# Altium schematic sheet TTFT_3.0.SchDoc(TTFT_3.0)
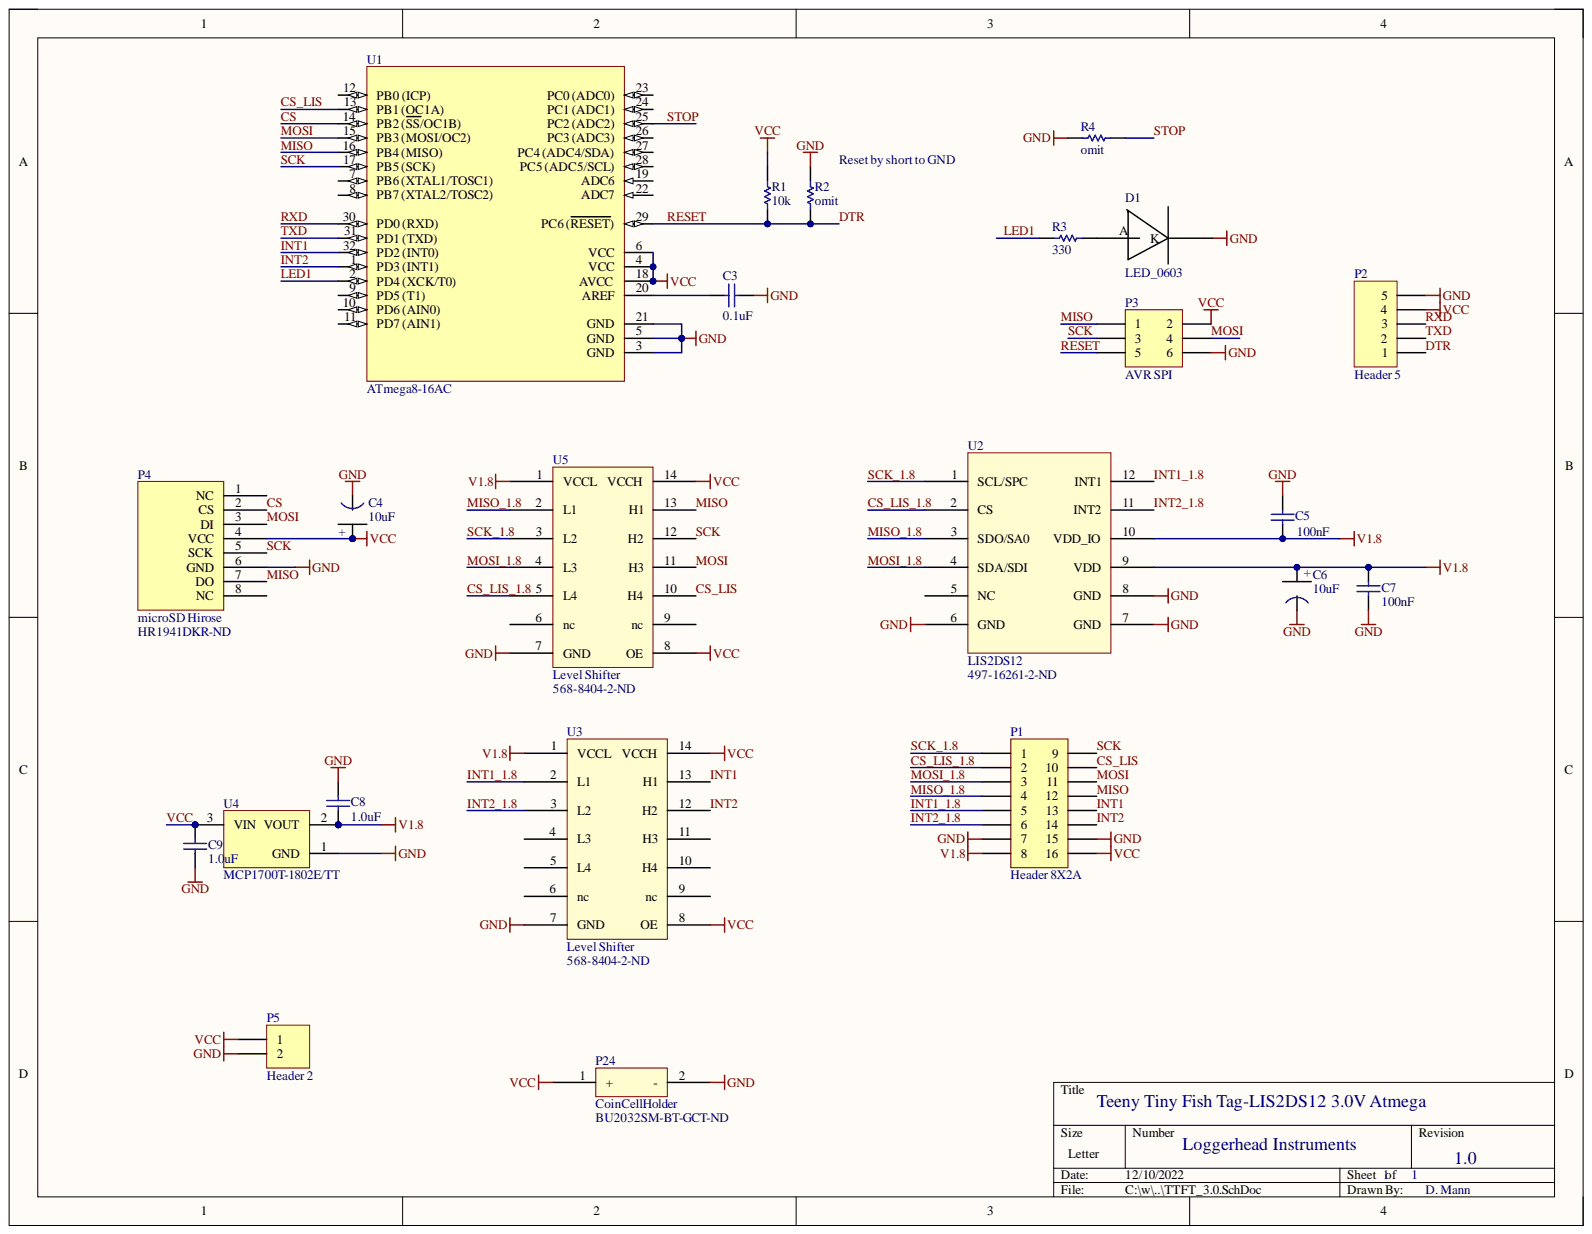
[diagram p0: TTFT_3.0.SchDoc]

## Parts
C3  0.1uF  [0402]
C4  10uF  [Tant0805]
C5  100nF  [0402]
C6  10uF  [Tant0805]
C7  100nF  [0402]
C8  1.0uF  [0402]
C9  1.0uF  [0402]
D1  LED_0603  [Diode0603]
P1  Header 8X2A  [HDR2X8_CEN]
P2  Header 5  [HDR1X5]
P3  AVR SPI  [HDR2X3]
P4  microSD Hirose  Supplier Part Number 1: HR1941DKR-ND  [DM3D microSD]
P5  Header 2  [HDR1X2]
P24  CoinCellHolder  Supplier Part Number 1: BU2032SM-BT-GCT-ND  [CoinCellHolder]
R1  10k  [3-0603]
R2  omit  [3-0603]
R3  330  [3-0603]
R4  omit  [3-0603]
U1  ATmega8-16AC  [32M1-A_M]
U2  LIS2DS12  Supplier Part Number 1: 497-16261-2-ND  [LGA-12]
U3  Level Shifter  Supplier Part Number 1: 568-8404-2-ND  [DHVQFN14]
U4  MCP1700T-1802E/TT  [SOT-23-TT3_N]
U5  Level Shifter  Supplier Part Number 1: 568-8404-2-ND  [DHVQFN14]

## Nets
GND: C3.2 C4.1 C5.2 C6.1 C7.2 C8.2 C9.2 D1.K P1.7 P1.15 P2.5 P3.6 P4.6(GND) P5.2 P24.2 R2.2 R4.2 U1.3(GND) U1.5(GND) U1.21(GND) U2.6(GND) U2.7(GND) U2.8(GND) U3.7(GND) U4.1 U5.7(GND)
V1.8: C5.1 C6.2 C7.1 C8.1 P1.8 U2.9(VDD) U2.10(VDD_IO) U3.1(VCCL) U4.2 U5.1(VCCL)
CS: P4.2(CS) U1.14(PB2_(SS/OC1B))
CS_LIS: P1.10 U1.13(PB1_(OC1A)) U5.10(H4)
CS_LIS_1.8: P1.2 U2.2(CS) U5.5(L4)
DTR: P2.1 P3.5 R1.1 R2.1 U1.29(PC6_(RESET))
INT1: P1.13 U1.32(PD2_(INT0)) U3.13(H1)
INT1_1.8: P1.5 U2.12(INT1) U3.2(L1)
INT2: P1.14 U1.1(PD3_(INT1)) U3.12(H2)
INT2_1.8: P1.6 U2.11(INT2) U3.3(L2)
LED1: R3.1 U1.2(PD4_(XCK/T0))
MISO: P1.12 P3.1 P4.7(DO) U1.16(PB4_(MISO)) U5.13(H1)
MISO_1.8: P1.4 U2.3(SDO/SA0) U5.2(L1)
MOSI: P1.11 P3.4 P4.3(DI) U1.15(PB3_(MOSI/OC2)) U5.11(H3)
MOSI_1.8: P1.3 U2.4(SDA/SDI) U5.4(L3)
RXD: P2.3 U1.30(PD0_(RXD))
SCK: P1.9 P3.3 P4.5(SCK) U1.17(PB5_(SCK)) U5.12(H2)
SCK_1.8: P1.1 U2.1(SCL/SPC) U5.3(L2)
STOP: R4.1 U1.25(PC2_(ADC2))
TXD: P2.2 U1.31(PD1_(TXD))
VCC: C4.2 C9.1 P1.16 P2.4 P3.2 P4.4(VCC) P5.1 P24.1 R1.2 U1.4(VCC) U1.6(VCC) U1.18(AVCC) U3.8(OE) U3.14(VCCH) U4.3 U5.8(OE) U5.14(VCCH)
NetC3_1: C3.1 U1.20(AREF)
NetD1_A: D1.A R3.2

Not connected: P4 1(NC) 8(NC); U1 7(PB6_(XTAL1/TOSC1)) 8(PB7_(XTAL2/TOSC2)) 9(PD5_(T1)) 10(PD6_(AIN0)) 11(PD7_(AIN1)) 12(PB0_(ICP)) 19(ADC6) 22(ADC7) 23(PC0_(ADC0)) 24(PC1_(ADC1)) 26(PC3_(ADC3)) 27(PC4_(ADC4/SDA)) 28(PC5_(ADC5/SCL)); U2 5(NC); U3 4(L3) 5(L4) 6(nc) 9(nc) 10(H4) 11(H3); U5 6(nc) 9(nc)

## Library data of the parts
C3 = C0402: Ceramic Chip Capacitor - Standard; Note: Footprint corresponds to dimensions for bulk cassette packaging. This case size has tightened tolerances.; Code_IPC: 1005 [0402]; Code_JEITA: 0402; Component Kind: Standard; ComponentLink1Description: Manufacturer Link; ComponentLink1URL: http://www.kemet.com/; ComponentLink2Description: Datasheet; ComponentLink2URL: http://www.kemet.com/kemet/web/homepage/kechome.nsf/vapubfilesname/F3102TA.pdf/$file/F3102TA.pdf; DatasheetDocument: Mar-2001; LatestRevisionDate: 19-Mar-2004; LatestRevisionNote: Silkscreen size reduced.; Library Name: KEMET Chip Capacitor.IntLib; PackageDocument: Mar-2001; PackageReference: 0402; Published: 17-Jul-2002; Signal Integrity: C0402; Simulation: CAP; Value: 0.1uF; Publisher: Altium Limited
C4 C6 = Tant0805: Component Kind: Standard; Value: 10uF; Library Name: Loggerhead.IntLib
C5 C7 = C0402: Ceramic Chip Capacitor - Standard; Note: Footprint corresponds to dimensions for bulk cassette packaging. This case size has tightened tolerances.; Value: 100pF; Code_IPC: 1005 [0402]; Code_JEITA: 0402; Component Kind: Standard; ComponentLink1Description: Manufacturer Link; ComponentLink1URL: http://www.kemet.com/; ComponentLink2Description: Datasheet; ComponentLink2URL: http://www.kemet.com/kemet/web/homepage/kechome.nsf/vapubfilesname/F3102TA.pdf/$file/F3102TA.pdf; DatasheetDocument: Mar-2001; LatestRevisionDate: 19-Mar-2004; LatestRevisionNote: Silkscreen size reduced.; Library Name: KEMET Chip Capacitor.IntLib; PackageDocument: Mar-2001; PackageReference: 0402; Published: 17-Jul-2002; Signal Integrity: C0402; Simulation: CAP; Publisher: Altium Limited
C8 C9 = C0402: Ceramic Chip Capacitor - Standard; Note: Footprint corresponds to dimensions for bulk cassette packaging. This case size has tightened tolerances.; Value: 0.1uF; Code_IPC: 1005 [0402]; Code_JEITA: 0402; Component Kind: Standard; ComponentLink1Description: Manufacturer Link; ComponentLink1URL: http://www.kemet.com/; ComponentLink2Description: Datasheet; ComponentLink2URL: http://www.kemet.com/kemet/web/homepage/kechome.nsf/vapubfilesname/F3102TA.pdf/$file/F3102TA.pdf; DatasheetDocument: Mar-2001; LatestRevisionDate: 19-Mar-2004; LatestRevisionNote: Silkscreen size reduced.; Library Name: KEMET Chip Capacitor.IntLib; PackageDocument: Mar-2001; PackageReference: 0402; Published: 17-Jul-2002; Signal Integrity: C0402; Simulation: CAP; Publisher: Altium Limited
P1 = Header 8X2A: Header, 8-Pin, Dual row; Component Kind: Standard; LatestRevisionDate: 17-Jul-2002; LatestRevisionNote: Re-released for DXP Platform.; Library Name: Miscellaneous Connectors.IntLib; Signal Integrity: Connector; Publisher: Altium Limited
P2 = Header 5: Header, 5-Pin; Component Kind: Standard; LatestRevisionDate: 17-Jul-2002; LatestRevisionNote: Re-released for DXP Platform.; Library Name: Miscellaneous Connectors.IntLib; Signal Integrity: Connector; Publisher: Altium Limited
P3 = Header 3X2: Header, 3-Pin, Dual row; Component Kind: Standard; LatestRevisionDate: 17-Jul-2002; LatestRevisionNote: Re-released for DXP Platform.; Library Name: Miscellaneous Connectors.IntLib; Signal Integrity: Connector; Publisher: Altium Limited
P5 = Header 2: Header, 2-Pin; Component Kind: Standard; LatestRevisionDate: 17-Jul-2002; LatestRevisionNote: Re-released for DXP Platform.; Library Name: Miscellaneous Connectors.IntLib; Signal Integrity: Connector; Publisher: Altium Limited
R1-R4 = ERJ3RK: Precision Thick Film Chip Resistor, 10 Ohm to 1M Ohm Range, 1% and 0.5% Tolerance, 0603 Size, 0.063 W; Code_IPC: 1608 [0603]; Component Kind: Standard; ComponentLink1Description: Manufacturer Link; ComponentLink1URL: http://www.panasonic.com/industrial/components/resistive_products.htm; ComponentLink2Description: Datasheet; ComponentLink2URL: http://www.panasonic.com/industrial/components/pdf/AOA0000CE2.pdf; LatestRevisionDate: 19-Mar-2004; LatestRevisionNote: Silkscreen size reduced.; Library Name: Panasonic Resistor.IntLib; PackageDocument: Mar-2003; PackageReference: 3-0603; Published: 17-Dec-2003; Signal Integrity: Res; Simulation: RESISTOR; Publisher: Altium Limited
U1 = ATmega8-16AC: 8-Bit AVR Microcontroller with 8K Bytes of In-System Programmable Flash Memory; Code_JEDEC: MS-026-ABA; Component Kind: Standard; ComponentLink1Description: Manufacturer Link; ComponentLink1URL: http://www.atmel.com; ComponentLink2Description: Datasheet; ComponentLink2URL: http://www.atmel.com/dyn/resources/prod_documents/doc2486.pdf; DatasheetVersion: 2486H-AVR-09/02; LatestRevisionDate: 13-Apr-2006; LatestRevisionNote: IPC-7351 Footprint Added.; Library Name: Atmel Microcontroller 8-Bit AVR.IntLib; PackageDescription: 32-Pin Thin Quad Flat Pack 0.8 mm Pitch; PackageReference: 32A; PackageVersion: revB, Oct-2001; PCB3D: ATMEGA8-32A; Published: 17-Sep-2003; Publisher: Altium Limited
U3 U5 = NTB0104: Voltage level translator; Component Kind: Standard; Supplier 1: Digi-Key; Library Name: Loggerhead.IntLib
U4 = MCP1700T-1802E/TT: Low Quiescent Current LDO, 3-Pin SOT-23, Extended Temperature, Tape and Reel; Manufacturer: Microchip; Code_IPC: SOT23-3; Component Kind: Standard; ComponentLink1Description: Manufacturer URL; ComponentLink1URL: http://www.microchip.com/; ComponentLink2Description: Datasheet; ComponentLink2URL: http://ww1.microchip.com/downloads/en/DeviceDoc/21826b.pdf; ComponentLink3Description: Package Specification; ComponentLink3URL: http://www.microchip.com/stellent/groups/techpub_sg/documents/packagingspec/en012702.pdf; DatasheetVersion: revB, Feb-2007; Library Name: Microchip Power Management Line Regulator.IntLib; Mounting Technology: Surface Mount; PackageDescription: 3-Lead Plastic Small Outline Transistor (TT) [SOT-23]; PackageReference: SOT-23-TT3; PackageVersion: revBB, Aug-2009; PartNumber: MCP1700T-1802E/TT; Supplier 1: Digi-Key; Supplier 2: Digi-Key; Supplier 3: Digi-Key; Supplier 4: Farnell; Supplier 5: Farnell; Supplier 6: Newark; Supplier 7: Mouser; Supplier Part Number 1: MCP1700T1802ETTTR-ND; Supplier Part Number 2: MCP1700T1802ETTCT-ND; Supplier Part Number 3: MCP1700T1802ETTDKR-ND; Supplier Part Number 4: 1296591RL; Supplier Part Number 5: 1296591; Supplier Part Number 6: 84R5175; Supplier Part Number 7: 579-MCP1700T1802E/TT
D1 = LED_0603: Component Kind: Standard; Library Name: Loggerhead.SchLib
P4 = microSD Hirose: Component Kind: Standard; Supplier 1: Digi-Key; Library Name: Loggerhead.IntLib
P24 = CoinCellHolder: Component Kind: Standard; Supplier 1: Digi-Key; Library Name: Loggerhead.IntLib
U2 = LIS2DS12: Component Kind: Standard; Supplier 1: Digi-Key; Library Name: Loggerhead.IntLib
